# Altium schematic sheet FIFO.SchDoc(FIFO)
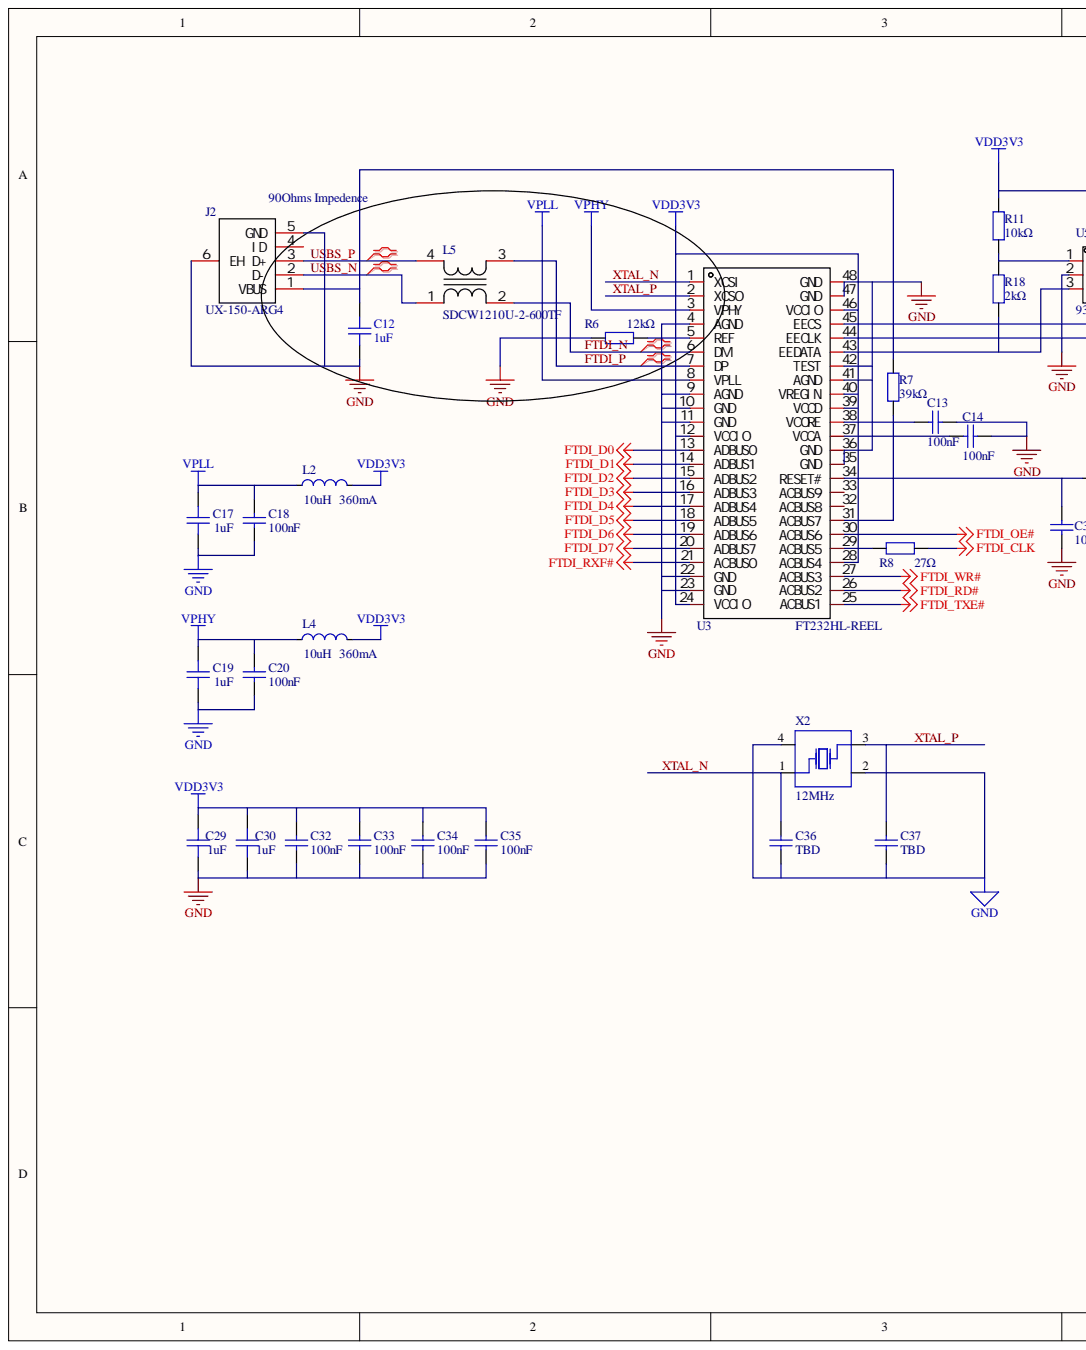
[diagram p0: FIFO.SchDoc, left]
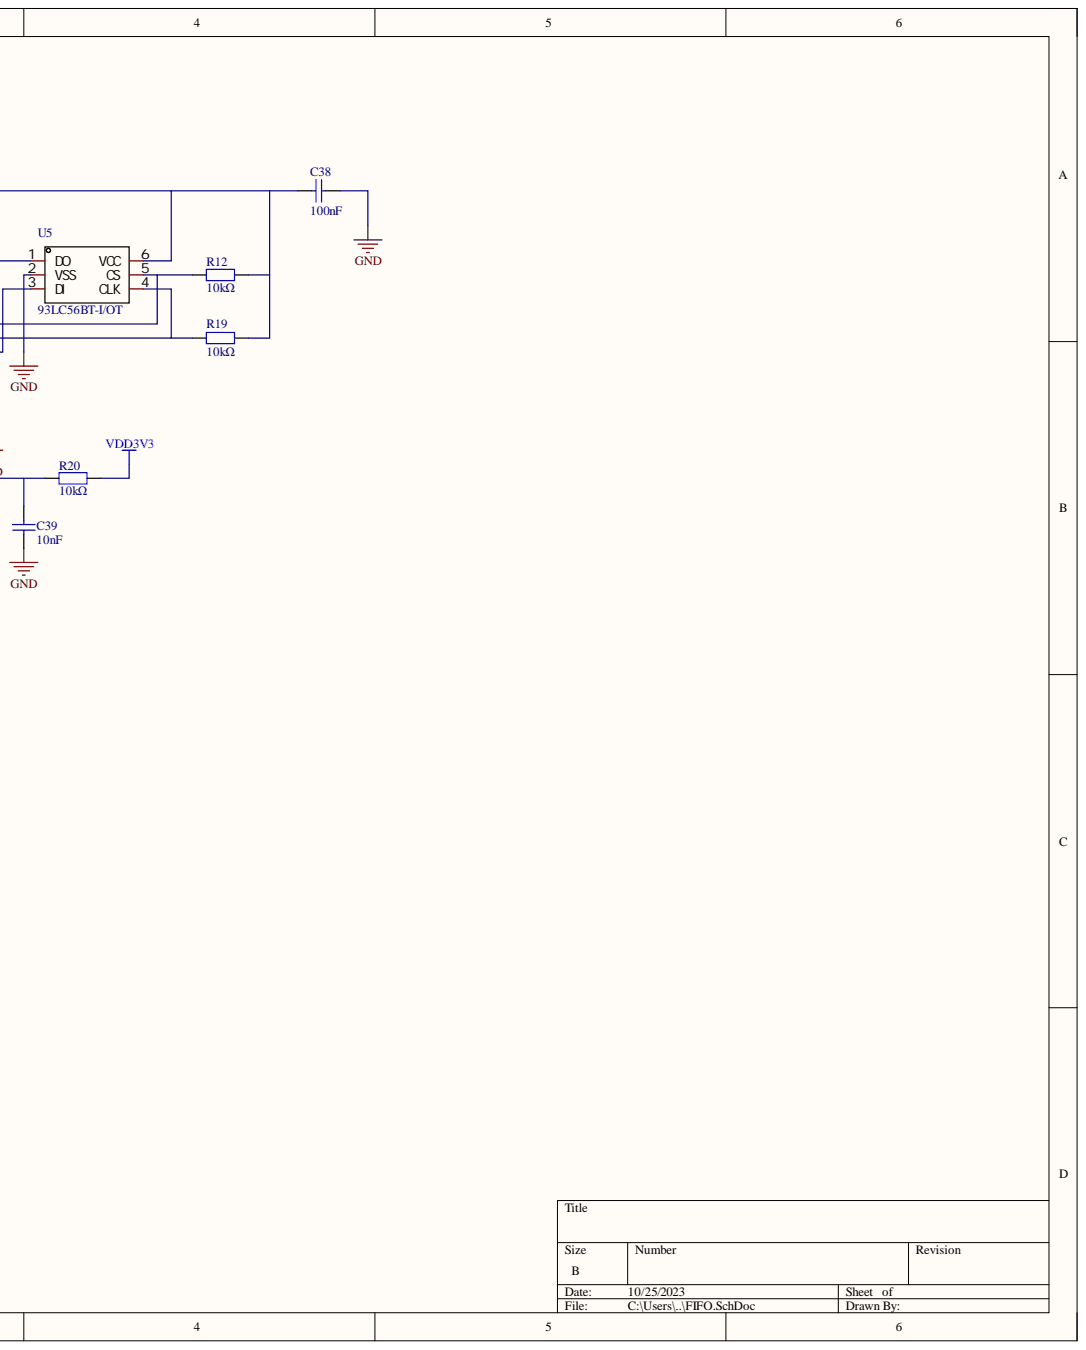
[diagram p1: FIFO.SchDoc, right]

## Parts
C12  value 1uF  [C0603]
C13  value 100nF  [C0402]
C14  value 100nF  [C0402]
C17  value 1uF  [C0603]
C18  value 100nF  [C0402]
C19  value 1uF  [C0603]
C20  value 100nF  [C0402]
C29  value 1uF  [C0603]
C30  value 1uF  [C0603]
C32  value 100nF  [C0402]
C33  value 100nF  [C0402]
C34  value 100nF  [C0402]
C35  value 100nF  [C0402]
C36  value TBD  [C0402]
C37  value TBD  [C0402]
C38  value 100nF  [C0402]
C39  value 10nF  [C0402]
J2  UX-150-ARG4  [MICRO-USB-TH_UX-150-ARG4]
L2  value 10uH  Rated Current: 360mA  [L0805]
L4  value 10uH  Rated Current: 360mA  [L0805]
L5  SDCW1210U-2-600TF  [FILTER-SMD_4P-L1.2-W1.0-BL]
R6  value 12kΩ  [R0402]
R7  value 39kΩ  [R0402]
R8  value 27Ω  [R0402]
R11  value 10kΩ  [R0402]
R12  value 10kΩ  [R0402]
R18  value 2kΩ  [R0402]
R19  value 10kΩ  [R0402]
R20  value 10kΩ  [R0402]
U3  FT232HL-REEL  [LQFP-48_L7.0-W7.0-P0.50-LS9.0-TL]
U5  93LC56BT-I/OT  [SOT-23-6_L2.9-W1.6-P0.95-LS2.8-BR]
X2  value 12MHz  [OSC-SMD_4P-L3.2-W2.5-BL]

## Nets
FTDI_CLK: R8.2
FTDI_D0: U3.13(ADBUS0)
FTDI_D1: U3.14(ADBUS1)
FTDI_D2: U3.15(ADBUS2)
FTDI_D3: U3.16(ADBUS3)
FTDI_D4: U3.17(ADBUS4)
FTDI_D5: U3.18(ADBUS5)
FTDI_D6: U3.19(ADBUS6)
FTDI_D7: U3.20(ADBUS7)
FTDI_OE#: U3.30(ACBUS6)
FTDI_RD#: U3.26(ACBUS2)
FTDI_RXF#: U3.21(ACBUS0)
FTDI_TXE#: U3.25(ACBUS1)
FTDI_WR#: U3.27(ACBUS3)
GND: C12.2 C13.1 C14.1 C17.1 C18.1 C19.1 C20.1 C29.1 C30.1 C32.1 C33.1 C34.1 C35.1 C36.2 C37.2 C38.1 C39.1 J2.5(GND) J2.6(EH) R6.1 U3.4(AGND) U3.9(AGND) U3.10(GND) U3.11(GND) U3.22(GND) U3.23(GND) U3.35(GND) U3.36(GND) U3.41(AGND) U3.42(TEST) U3.47(GND) U3.48(GND) U5.2(VSS) X2.2 X2.4
VDD3V3: C29.2 C30.2 C32.2 C33.2 C34.2 C35.2 C38.2 L2.2 L4.2 R11.2 R12.1 R19.1 R20.1 U3.12(VCCIO) U3.24(VCCIO) U3.28(ACBUS4) U3.39(VCCD) U3.40(VREGIN) U3.46(VCCIO) U5.6(VCC)
VPHY: C19.2 C20.2 L4.1 U3.3(VPHY)
VPLL: C17.2 C18.2 L2.1 U3.8(VPLL)
FTDI_N: L5.2 U3.6(DM)
FTDI_P: L5.3 U3.7(DP)
USBS_N: J2.2(D-) L5.1
USBS_P: J2.3(D+) L5.4
XTAL_N: C36.1 U3.1(XCSI) X2.1
XTAL_P: C37.1 U3.2(XCSO) X2.3
NetC12_1: C12.1 J2.1(VBUS) R7.2
NetC13_2: C13.2 U3.38(VCORE)
NetC14_2: C14.2 U3.37(VCCA)
NetC39_2: C39.2 R20.2 U3.34(RESET#)
NetR6_2: R6.2 U3.5(REF)
NetR7_1: R7.1 U3.31(ACBUS7)
NetR8_1: R8.1 U3.29(ACBUS5)
NetR11_1: R11.1 R18.2 U5.1(DO)
NetR12_2: R12.2 U3.45(EECS) U5.5(CS)
NetR18_1: R18.1 U3.43(EEDATA) U5.3(DI)
NetR19_2: R19.2 U3.44(EECLK) U5.4(CLK)

Not connected: J2 4(ID); U3 32(ACBUS8) 33(ACBUS9)

## Library data of the parts
C12 C17 C19 C29 C30 = CL05A105KA5NQNC: Manufacturer: SAMSUNG(三星); Add into BOM: yes; Temperature Coefficient: X5R; Tolerance: ±10%; Voltage Rated: 25V; Component Kind: Standard; Convert to PCB: yes; Datasheet: https://atta.szlcsc.com/upload/public/pdf/source/20160103/1457707735223.pdf; Device: CL05A105KA5NQNC; JLCPCB Part Class: Basic Part; LCSC Part Name: 1uF ±10% 25V; Manufacturer Part: CL05A105KA5NQNC; PCB3D: C0402_L1.0-W0.5-H0.5; Supplier: LCSC; Supplier Footprint: 0402; Supplier Part: C52923; Symbol: CL05A105KA5NQNC
C13 C14 C18 C20 C32-C35 C38 = CL05B104KO5NNNC: Manufacturer: SAMSUNG(三星); Add into BOM: yes; Temperature Coefficient: X7R; Tolerance: ±10%; Voltage Rated: 16V; Component Kind: Standard; Convert to PCB: yes; Datasheet: https://atta.szlcsc.com/upload/public/pdf/source/20160103/1457707674891.pdf; Device: CL05B104KO5NNNC; JLCPCB Part Class: Basic Part; LCSC Part Name: 100nF ±10% 16V; Manufacturer Part: CL05B104KO5NNNC; PCB3D: C0402_L1.0-W0.5-H0.5; Supplier: LCSC; Supplier Footprint: 0402; Supplier Part: C1525; Symbol: CL05B104KO5NNNC
C36 C37 = 0402CG150J500NT: Manufacturer: FH(风华); Add into BOM: yes; Temperature Coefficient: C0G; Tolerance: ±5%; Voltage Rated: 50V; Component Kind: Standard; Convert to PCB: yes; Datasheet: https://atta.szlcsc.com/upload/public/pdf/source/20170923/C1548_1506149266133988355.pdf; Device: 0402CG150J500NT; JLCPCB Part Class: Basic Part; LCSC Part Name: 15pF ±5% 50V; Manufacturer Part: 0402CG150J500NT; PCB3D: C0402_L1.0-W0.5-H0.5; Supplier: LCSC; Supplier Footprint: 0402; Supplier Part: C1548; Symbol: 0402CG150J500NT
C39 = 0402CG101J500NT: Manufacturer: FH(风华); Add into BOM: yes; Temperature Coefficient: C0G; Tolerance: ±5%; Voltage Rated: 50V; Component Kind: Standard; Convert to PCB: yes; Datasheet: https://atta.szlcsc.com/upload/public/pdf/source/20170923/C1546_1506149265265988353.pdf; Device: 0402CG101J500NT; JLCPCB Part Class: Basic Part; LCSC Part Name: 100pF ±5% 50V; Manufacturer Part: 0402CG101J500NT; PCB3D: C0402_L1.0-W0.5-H0.5; Supplier: LCSC; Supplier Footprint: 0402; Supplier Part: C1546; Symbol: 0402CG101J500NT
J2 = UX-150-ARG4: Manufacturer: XUNPU(讯普); Add into BOM: yes; Connector Type: Mini-B; Current Rating - Power (Max): 1.5A; Gender: 母座; Number of Contacts: 5; Number of Ports: 1; Welding Temperature (Max): 260℃; Component Kind: Standard; Contact Material: -; Contact Plating: -; Convert to PCB: yes; Device: UX-150-ARG4; JLCPCB Part Class: Extended Part; LCSC Part Name: Mini-B 母座 弯插; Manufacturer Part: UX-150-ARG4; Mounting Style: 弯插; Name: UX-150-ARG4; Operating Temperature Range: -20℃~+70℃; PCB3D: MICRO-USB-TH_UX-150-ARG4; Standard: USB 2.0; Supplier: LCSC; Supplier Footprint: 插件,9.2x7.7x3.9mm; Supplier Part: C720612; Symbol: UX-150-ARG4
L2 L4 = SLM2012100MIT: Manufacturer: Sunltech(韩国顺磁); Add into BOM: yes; DC Resistance (DCR): 550mΩ; Tolerance: ±20%; Component Kind: Standard; Convert to PCB: yes; Datasheet: https://atta.szlcsc.com/upload/public/pdf/source/20180528/C216156_746607D01E5EB4C50E0D2BE40685D2FE.pdf; Device: SLM2012100MIT; JLCPCB Part Class: Extended Part; LCSC Part Name: 10uH ±20% 360mA 550mΩ; Manufacturer Part: SLM2012100MIT; PCB3D: R0805_L2.0-W1.3-H0.6; Ratings: -; Saturation Current (Isat): -; Supplier: LCSC; Supplier Footprint: 0805; Supplier Part: C2831365; Symbol: SLM2012100MIT
L5 = SDCW1210U-2-600TF: Manufacturer: Sunlord(顺络); Add into BOM: yes; Current Rating: 300mA; DC Resistance (DCR): 400mΩ; Impedance @ Frequency: 60Ω@100MHz; Number of Lines: 2; Voltage Rating - DC: 20V; Component Kind: Standard; Convert to PCB: yes; Datasheet: https://atta.szlcsc.com/upload/public/pdf/source/20210916/28FAB530282A61D66E1D2F3B7F172455.pdf; Device: SDCW1210U-2-600TF; JLCPCB Part Class: Extended Part; LCSC Part Name: 两路 0504共模滤波器 阻抗60Ω@100MHz; Manufacturer Part: SDCW1210U-2-600TF; Name: SDCW1210U-2-600TF; Operating Temperature: -40℃~+85℃; PCB3D: FILTER-SMD_4P-L1.2-W1.0-H0.8-BL; Supplier: LCSC; Supplier Footprint: 0504; Supplier Part: C843589; Symbol: SDCW1210U-2-600TF
R6-R8 R11 R12 R18-R20 = 0603WAF200JT5E: Manufacturer: UNI-ROYAL(厚声); Add into BOM: yes; Overload Voltage (Max): 75V; Power(Watts): 1/10W; Temperature Coefficient: ±100ppm/; Tolerance: ±1%; Component Kind: Standard; Convert to PCB: yes; Datasheet: https://atta.szlcsc.com/upload/public/pdf/source/20200306/C422600_1E6D84923E4A46A82E41ADD87F860B5C.pdf; Device: 0603WAF200JT5E; JLCPCB Part Class: Basic Part; LCSC Part Name: 20Ω ±1%; Manufacturer Part: 0603WAF200JT5E; Operating Temperature Range: -55~+155; PCB3D: R0603_L1.6-W0.8-H0.8; Supplier: LCSC; Supplier Footprint: 0603; Supplier Part: C22950; Symbol: 0603WAF200JT5E; Type: 厚膜电阻
U3 = FT232HL-REEL: Manufacturer: FTDI(飞特帝亚); Add into BOM: yes; Component Kind: Standard; Convert to PCB: yes; Data Rate: -; Datasheet: https://atta.szlcsc.com/upload/public/pdf/source/20150618/1457707324308.pdf; Device: FT232HL-REEL; JLCPCB Part Class: Extended Part; LCSC Part Name: FT232HL-REEL; Manufacturer Part: FT232HL-REEL; Name: FT232HL-REEL; Number of Drivers/Receivers: -; PCB3D: LQFP-48_L7.0-W7.0-H1.4-LS9.0-P0.50; Protocol: -; Signal Integrity: Guessed Model; Supplier: LCSC; Supplier Footprint: LQFP-48(7x7); Supplier Part: C51997; Supply Voltage: -; Symbol: FT232HL; Type: -
U5 = 93LC56BT-I/OT: Manufacturer: MICROCHIP(美国微芯); Add into BOM: yes; Component Kind: Standard; Convert to PCB: yes; Datasheet: https://atta.szlcsc.com/upload/public/pdf/source/20180423/C190271_ABAD329DACFE2165969EA1B02E3F54C8.pdf; Device: 93LC56BT-I/OT; JLCPCB Part Class: Extended Part; LCSC Part Name: 93LC56BT-I/OT; Manufacturer Part: 93LC56BT-I/OT; Name: 93LC56BT-I/OT; PCB3D: SOT-23-6_L2.9-W1.6-H1.5-LS2.8-P0.95; Supplier: LCSC; Supplier Footprint: SOT-23-6; Supplier Part: C190271; Symbol: 93LC56BT-I/OT
X2 = X322525MOB4SI: Manufacturer: YXC扬兴科技; Add into BOM: yes; Crystal Type: 贴片无源晶振; Frequency Stability: ±30ppm; Frequency Tolerance: ±10ppm; Load Capacitance: 12pF; Component Kind: Standard; Convert to PCB: yes; Datasheet: https://atta.szlcsc.com/upload/public/pdf/source/20210329/C112971_1F611BDC486E193C1706F6D8EB5AA189.pdf; Device: X322525MOB4SI; JLCPCB Part Class: Basic Part; LCSC Part Name: 25MHz ±10ppm 12pF; Manufacturer Part: X322525MOB4SI; Operating Temperature: -40~+85; PCB3D: OSC-SMD_4P-L3.2-W2.5-H1.0; Supplier: LCSC; Supplier Footprint: SMD3225-4P; Supplier Part: C9006; Symbol: X322525MOB4SI
